# Altium schematic sheet Control-Principal.V03.R00.SchDoc
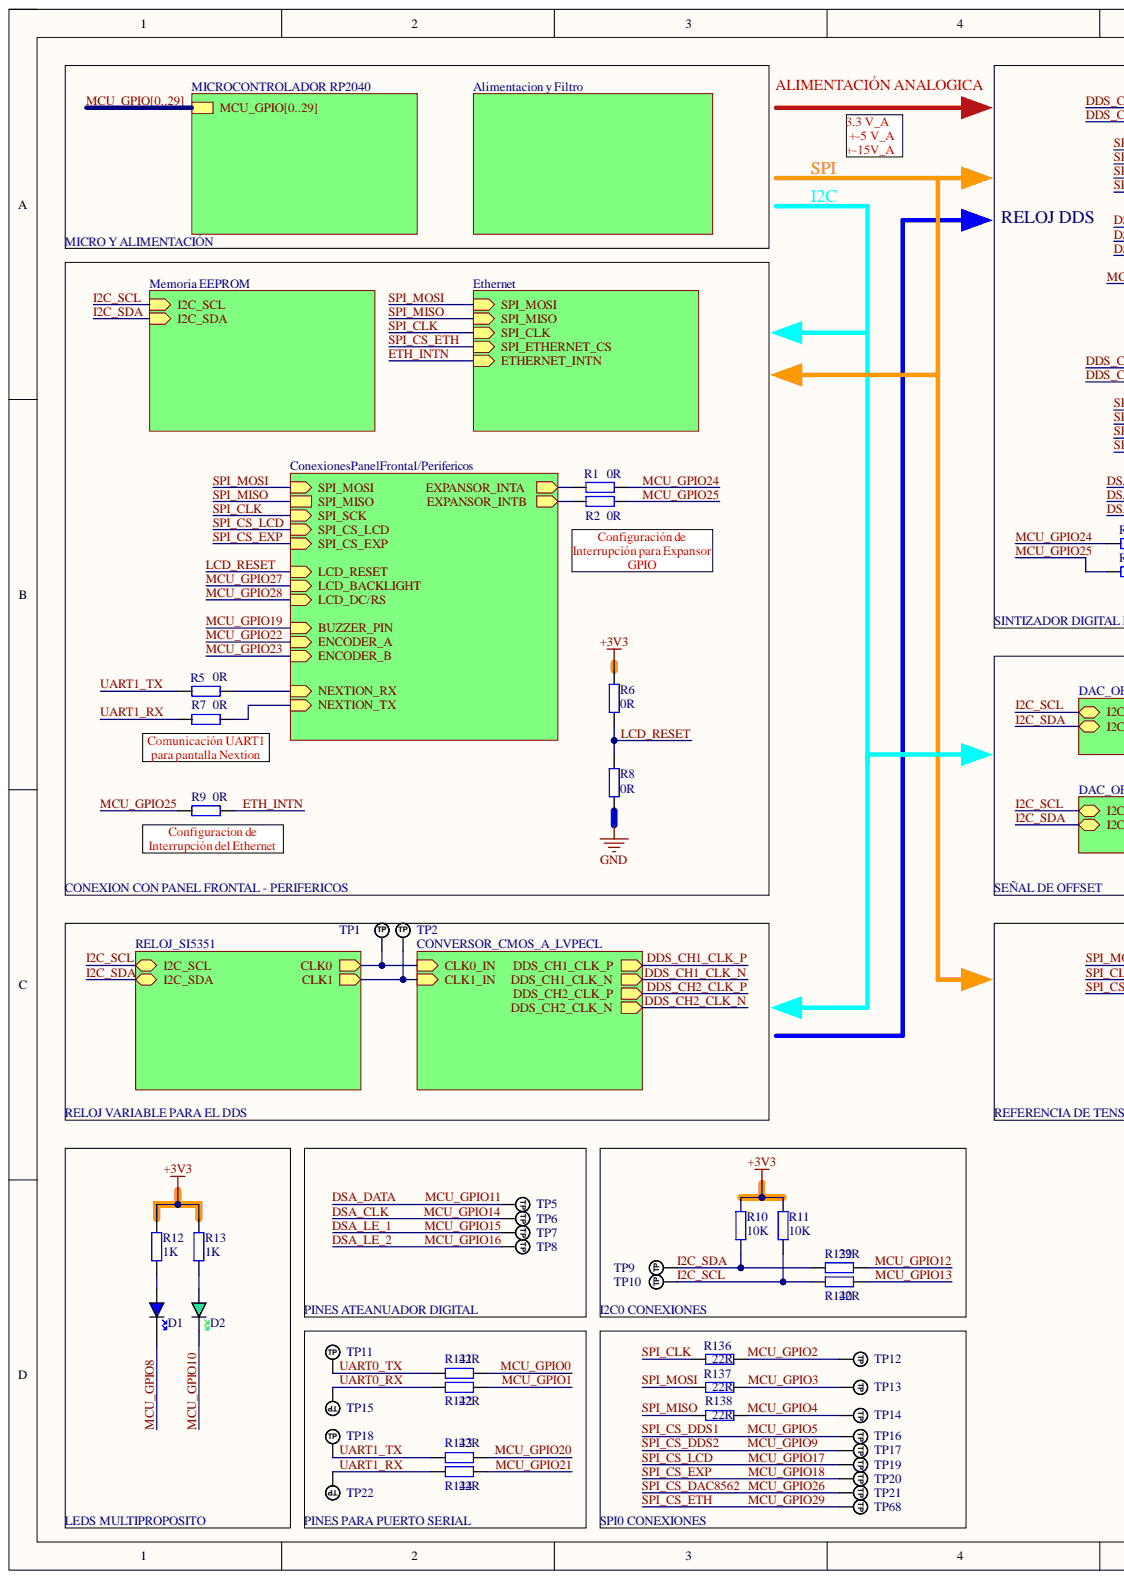
[diagram p0: Control-Principal.V03.R00.SchDoc, left]
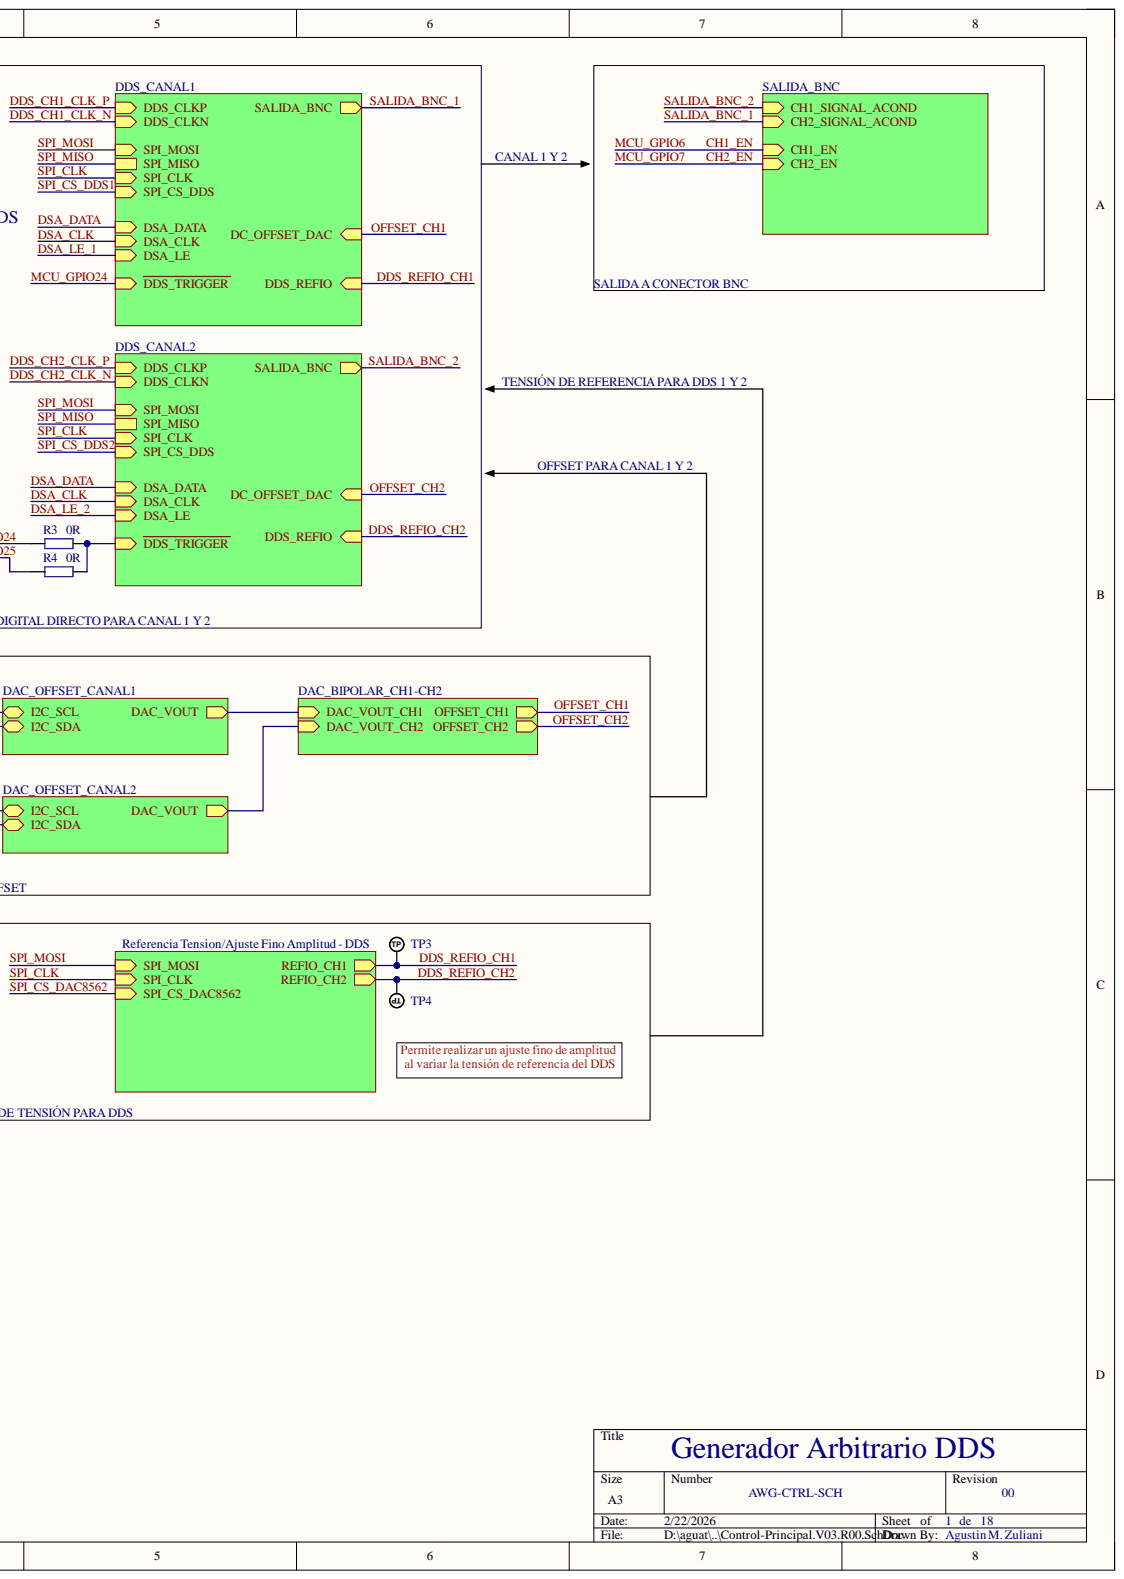
[diagram p1: Control-Principal.V03.R00.SchDoc, right]

## Parts
D1  BLUE  [LED_0805_BLUE]  (value not drawn)
D2  GREEN  [LED_0805_GREEN]  (value not drawn)
R1  0R  [RES_0805]
R2  0R  [RES_0805]
R3  0R  [RES_0805]
R4  0R  [RES_0805]
R5  0R  [RES_0805]
R6  0R  [RES_0805]
R7  0R  [RES_0805]
R8  0R  [RES_0805]
R9  0R  [RES_0805]
R10  10K  [RES_0805]
R11  10K  [RES_0805]
R12  1K  [RES_0805]
R13  1K  [RES_0805]
R136  22R  [RES_0805]
R137  22R  [RES_0805]
R138  22R  [RES_0805]
R139  22R  [RES_0805]
R140  22R  [RES_0805]
R141  22R  [RES_0805]
R142  22R  [RES_0805]
R143  22R  [RES_0805]
R144  22R  [RES_0805]
TP1  TP_NOBOM  [PAD_RND_1MM]  (value not drawn)  (no BOM)
TP2  TP_NOBOM  [PAD_RND_1MM]  (value not drawn)  (no BOM)
TP3  TP_NOBOM  [PAD_RND_1MM]  (value not drawn)  (no BOM)
TP4  TP_NOBOM  [PAD_RND_1MM]  (value not drawn)  (no BOM)
TP5  TP_NOBOM  [PAD_RND_1MM]  (value not drawn)  (no BOM)
TP6  TP_NOBOM  [PAD_RND_1MM]  (value not drawn)  (no BOM)
TP7  TP_NOBOM  [PAD_RND_1MM]  (value not drawn)  (no BOM)
TP8  TP_NOBOM  [PAD_RND_1MM]  (value not drawn)  (no BOM)
TP9  TP_NOBOM  [PAD_RND_1MM]  (value not drawn)  (no BOM)
TP10  TP_NOBOM  [PAD_RND_1MM]  (value not drawn)  (no BOM)
TP11  TP_NOBOM  [PAD_RND_1MM]  (value not drawn)  (no BOM)
TP12  TP_NOBOM  [PAD_RND_1MM]  (value not drawn)  (no BOM)
TP13  TP_NOBOM  [PAD_RND_1MM]  (value not drawn)  (no BOM)
TP14  TP_NOBOM  [PAD_RND_1MM]  (value not drawn)  (no BOM)
TP15  TP_NOBOM  [PAD_RND_1MM]  (value not drawn)  (no BOM)
TP16  TP_NOBOM  [PAD_RND_1MM]  (value not drawn)  (no BOM)
TP17  TP_NOBOM  [PAD_RND_1MM]  (value not drawn)  (no BOM)
TP18  TP_NOBOM  [PAD_RND_1MM]  (value not drawn)  (no BOM)
TP19  TP_NOBOM  [PAD_RND_1MM]  (value not drawn)  (no BOM)
TP20  TP_NOBOM  [PAD_RND_1MM]  (value not drawn)  (no BOM)
TP21  TP_NOBOM  [PAD_RND_1MM]  (value not drawn)  (no BOM)
TP22  TP_NOBOM  [PAD_RND_1MM]  (value not drawn)  (no BOM)
TP68  TP_NOBOM  [PAD_RND_1MM]  (value not drawn)  (no BOM)

## Nets
+3V3: R6.1 R10.2 R11.2 R12.2 R13.2
GND: R8.1
DDS_REFIO_CH1: TP3.1(TP)
DDS_REFIO_CH2: TP4.1(TP)
ETH_INTN: R9.2
I2C_SCL: R11.1 R140.1 TP10.1(TP)
I2C_SDA: R10.1 R139.1 TP9.1(TP)
LCD_RESET: R6.2 R8.2
MCU_GPIO0: R141.2  [also labelled MCU_GPIO]
MCU_GPIO0: R141.2  [also labelled MCU_GPIO]
MCU_GPIO1: R142.2  [also labelled MCU_GPIO]
MCU_GPIO1: R142.2  [also labelled MCU_GPIO]
MCU_GPIO2: R136.2 TP12.1(TP)  [also labelled MCU_GPIO]
MCU_GPIO2: R136.2 TP12.1(TP)  [also labelled MCU_GPIO]
MCU_GPIO3: R137.2 TP13.1(TP)  [also labelled MCU_GPIO]
MCU_GPIO3: R137.2 TP13.1(TP)  [also labelled MCU_GPIO]
MCU_GPIO4: R138.2 TP14.1(TP)  [also labelled MCU_GPIO]
MCU_GPIO4: R138.2 TP14.1(TP)  [also labelled MCU_GPIO]
MCU_GPIO5: TP16.1(TP)  [also labelled MCU_GPIO]
MCU_GPIO5: TP16.1(TP)  [also labelled MCU_GPIO]
MCU_GPIO8: D1.K  [also labelled MCU_GPIO]
MCU_GPIO8: D1.K  [also labelled MCU_GPIO]
MCU_GPIO9: TP17.1(TP)  [also labelled MCU_GPIO]
MCU_GPIO9: TP17.1(TP)  [also labelled MCU_GPIO]
MCU_GPIO10: D2.K  [also labelled MCU_GPIO]
MCU_GPIO10: D2.K  [also labelled MCU_GPIO]
MCU_GPIO11: TP5.1(TP)  [also labelled MCU_GPIO]
MCU_GPIO11: TP5.1(TP)  [also labelled MCU_GPIO]
MCU_GPIO12: R139.2  [also labelled MCU_GPIO]
MCU_GPIO12: R139.2  [also labelled MCU_GPIO]
MCU_GPIO13: R140.2  [also labelled MCU_GPIO]
MCU_GPIO13: R140.2  [also labelled MCU_GPIO]
MCU_GPIO14: TP6.1(TP)  [also labelled MCU_GPIO]
MCU_GPIO14: TP6.1(TP)  [also labelled MCU_GPIO]
MCU_GPIO15: TP7.1(TP)  [also labelled MCU_GPIO]
MCU_GPIO15: TP7.1(TP)  [also labelled MCU_GPIO]
MCU_GPIO16: TP8.1(TP)  [also labelled MCU_GPIO]
MCU_GPIO16: TP8.1(TP)  [also labelled MCU_GPIO]
MCU_GPIO17: TP19.1(TP)  [also labelled MCU_GPIO]
MCU_GPIO17: TP19.1(TP)  [also labelled MCU_GPIO]
MCU_GPIO18: TP20.1(TP)  [also labelled MCU_GPIO]
MCU_GPIO18: TP20.1(TP)  [also labelled MCU_GPIO]
MCU_GPIO20: R143.2  [also labelled MCU_GPIO]
MCU_GPIO20: R143.2  [also labelled MCU_GPIO]
MCU_GPIO21: R144.2  [also labelled MCU_GPIO]
MCU_GPIO21: R144.2  [also labelled MCU_GPIO]
MCU_GPIO24: R1.2 R3.1  [also labelled MCU_GPIO]
MCU_GPIO24: R1.2 R3.1  [also labelled MCU_GPIO]
MCU_GPIO25: R2.2 R4.1 R9.1  [also labelled MCU_GPIO]
MCU_GPIO25: R2.2 R4.1 R9.1  [also labelled MCU_GPIO]
MCU_GPIO26: TP21.1(TP)  [also labelled MCU_GPIO]
MCU_GPIO26: TP21.1(TP)  [also labelled MCU_GPIO]
MCU_GPIO29: TP68.1(TP)  [also labelled MCU_GPIO]
MCU_GPIO29: TP68.1(TP)  [also labelled MCU_GPIO]
SPI_CLK: R136.1
SPI_MISO: R138.1
SPI_MOSI: R137.1
UART0_RX: R142.1 TP15.1(TP)
UART0_TX: R141.1 TP11.1(TP)
UART1_RX: R7.1 R144.1 TP22.1(TP)
UART1_TX: R5.1 R143.1 TP18.1(TP)
NetD13_A: D1.A R12.1
NetD14_A: D2.A R13.1
NetR38_1: R1.1
NetR39_1: R2.1
NetR40_2: R3.2 R4.2
NetR42_2: R5.2
NetR44_2: R7.2
NetTP24_1: TP1.1(TP)
NetTP25_1: TP2.1(TP)
DAC_VOUT:
DDS_CH1_CLK_N:
DDS_CH1_CLK_P:
DDS_CH2_CLK_N:
DDS_CH2_CLK_P:
MCU_GPIO6:  [also labelled MCU_GPIO]
MCU_GPIO7:  [also labelled MCU_GPIO]
MCU_GPIO19:  [also labelled MCU_GPIO]
MCU_GPIO22:  [also labelled MCU_GPIO]
MCU_GPIO23:  [also labelled MCU_GPIO]
MCU_GPIO27:  [also labelled MCU_GPIO]
MCU_GPIO28:  [also labelled MCU_GPIO]
OFFSET_CH1:
OFFSET_CH2:
SALIDA_BNC_1:
SALIDA_BNC_2:

## Library data of the parts
D1 = LED_0805_BLUE: LED 0805 BLUE SMD; Component Kind: Standard; Library Name: Schematic-Lib-PhilsLab.SchLib
D2 = LED_0805_GREEN: LED 0805 GREEN SMD; Component Kind: Standard; Library Name: Schematic-Lib-PhilsLab.SchLib
R1-R13 R136-R144 = RES_0805: Resistor SMD 0805; Component Kind: Standard; Library Name: Schematic-Lib-PhilsLab.SchLib
TP1-TP22 TP68 = TEST_POINT_RND_1MM: Test Point Round 1mm (NO BOM); Library Name: Schematic-Lib-PhilsLab.SchLib
